# Altium schematic sheet PMSM_500W_Controller_Bridge.SchDoc
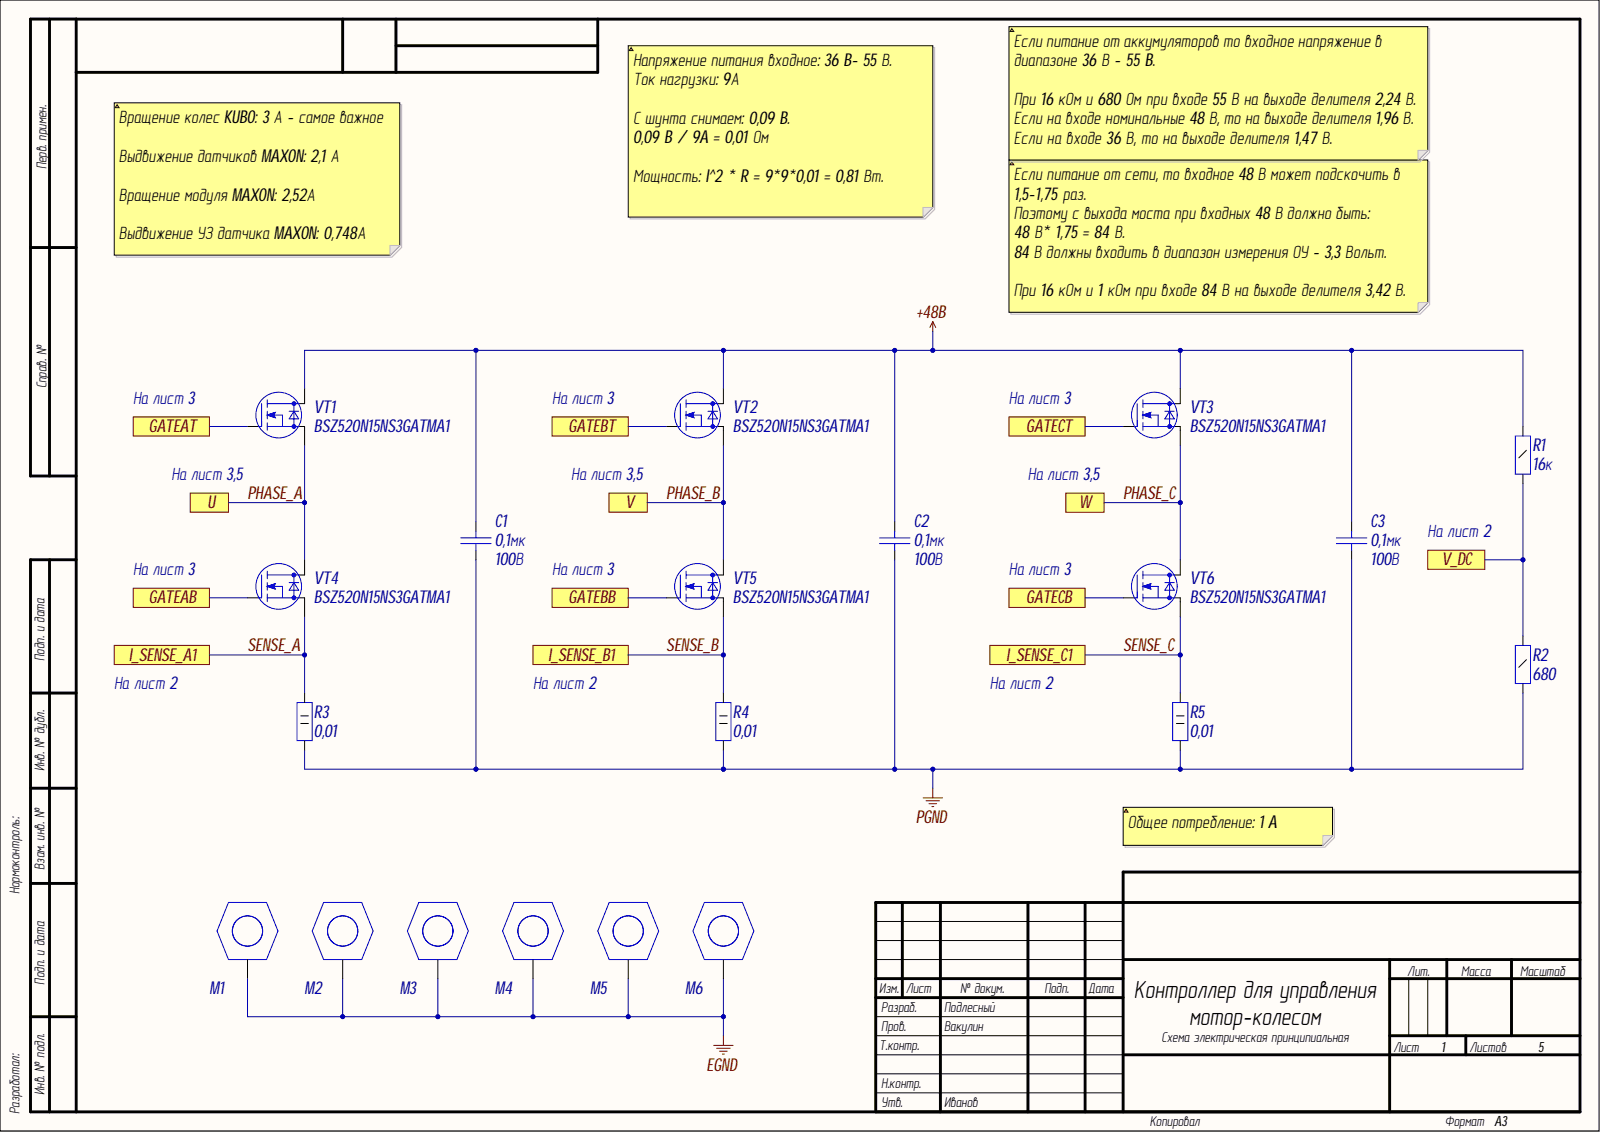
[diagram p0: PMSM_500W_Controller_Bridge.SchDoc]

## Parts
C1  0,1мк  Voltage: 100В  [C1206]
C2  0,1мк  Voltage: 100В  [C1206]
C3  0,1мк  Voltage: 100В  [C1206]
M1  SCREW  [SCREW3]  (value not drawn)
M2  SCREW  [SCREW3]  (value not drawn)
M3  SCREW  [SCREW3]  (value not drawn)
M4  SCREW  [SCREW3]  (value not drawn)
M5  SCREW  [SCREW3]  (value not drawn)
M6  SCREW  [SCREW3]  (value not drawn)
R1  value 16к  [R1206]  (hidden comment: Resistor_0,25Вт)
R2  value 680  [R1206]  (hidden comment: Resistor_0,25Вт)
R3  0,01  [R2512]
R4  0,01  [R2512]
R5  0,01  [R2512]
VT1  Part Number: BSZ520N15NS3GATMA1  [TSDSON8]  (hidden comment: N-MOSFET)
VT2  Part Number: BSZ520N15NS3GATMA1  [TSDSON8]  (hidden comment: N-MOSFET)
VT3  Part Number: BSZ520N15NS3GATMA1  [TSDSON8]  (hidden comment: N-MOSFET)
VT4  Part Number: BSZ520N15NS3GATMA1  [TSDSON8]  (hidden comment: N-MOSFET)
VT5  Part Number: BSZ520N15NS3GATMA1  [TSDSON8]  (hidden comment: N-MOSFET)
VT6  Part Number: BSZ520N15NS3GATMA1  [TSDSON8]  (hidden comment: N-MOSFET)

## Ports
GATEAB, GATEAT, GATEBB, GATEBT, GATECB, GATECT, I_SENSE_A1, I_SENSE_B1, I_SENSE_C1, U, V, V_DC, W

## Nets
+48B: C1.2 C2.2 C3.2 R1.2 VT1.2 VT2.2 VT3.2
EGND: M1.1 M2.1 M3.1 M4.1 M5.1 M6.1
PGND: C1.1 C2.1 C3.1 R2.1 R3.1 R4.1 R5.1
GATEAB: VT4.1 ; port GATEAB
GATEAT: VT1.1 ; port GATEAT
GATEBB: VT5.1 ; port GATEBB
GATEBT: VT2.1 ; port GATEBT
GATECB: VT6.1 ; port GATECB
GATECT: VT3.1 ; port GATECT
PHASE_A: VT1.3 VT4.2 ; port U
PHASE_B: VT2.3 VT5.2 ; port V
PHASE_C: VT3.3 VT6.2 ; port W
SENSE_A: R3.2 VT4.3 ; port I_SENSE_A1
SENSE_B: R4.2 VT5.3 ; port I_SENSE_B1
SENSE_C: R5.2 VT6.3 ; port I_SENSE_C1
V_DC: R1.1 R2.2 ; port V_DC

## Library data of the parts
C1-C3 = cap286: Конденсатор; Manufacture: Murata; Part Name: Ceramic; Part Number: GRM31C5C2A104JA01L; Database Table Name: Capacitors$; TKE: NP0; Component Kind: Standard; id: cap286; Library Name: MOP44.DbLib; Value: 0,1мк
M1-M6 = connect89: Part Name: Винт М3 с заземлением; Database Table Name: Connector$; Component Kind: Standard; id: connect89; Library Name: MOP44.DbLib
R1 = res685: Резистор; Manufacture: Yageo; Part Number: RC1206FR-0716KL; Accuracy: 0,01; Database Table Name: Resistor$; Power: 0,25Вт; Component Kind: Standard; id: res685; Library Name: MOP44.DbLib; Part Name: Resistor
R2 = res652: Резистор; Manufacture: Yageo; Part Number: RC1206FR-07680RL; Accuracy: 0,01; Database Table Name: Resistor$; Power: 0,25Вт; Component Kind: Standard; id: res652; Library Name: MOP44.DbLib; Part Name: Resistor
R3-R5 = res1169: Резистор; Manufacture: Bourns; Part Name: Shunt; Part Number: CRA2512-FZ-R010ELF; Database Table Name: Resistor$; Power: 2Вт; Accuracy: 0,01; Component Kind: Standard; id: res1169; Library Name: MOP44.DbLib; Value: 0,01
VT1-VT6 = mosfert23: Manufacturer: Infineon Technologies; Continuous drain current: 21A; Database Table Name: MOSFETTransistor$; Gate-to-source voltage: (+-)20B; Power dissipation: 57W; Pulsed drain current: 84A; Rds: 0,052Ohm; Structure: n-channel; Total gate charge: 12nC; V drain-source max: 150B; Component Kind: Standard; Description: N-MOSFET; id: mosfert23; Library Name: MOP44.DbLib
